# Altium schematic sheet SCH1.SchDoc(SCH1)
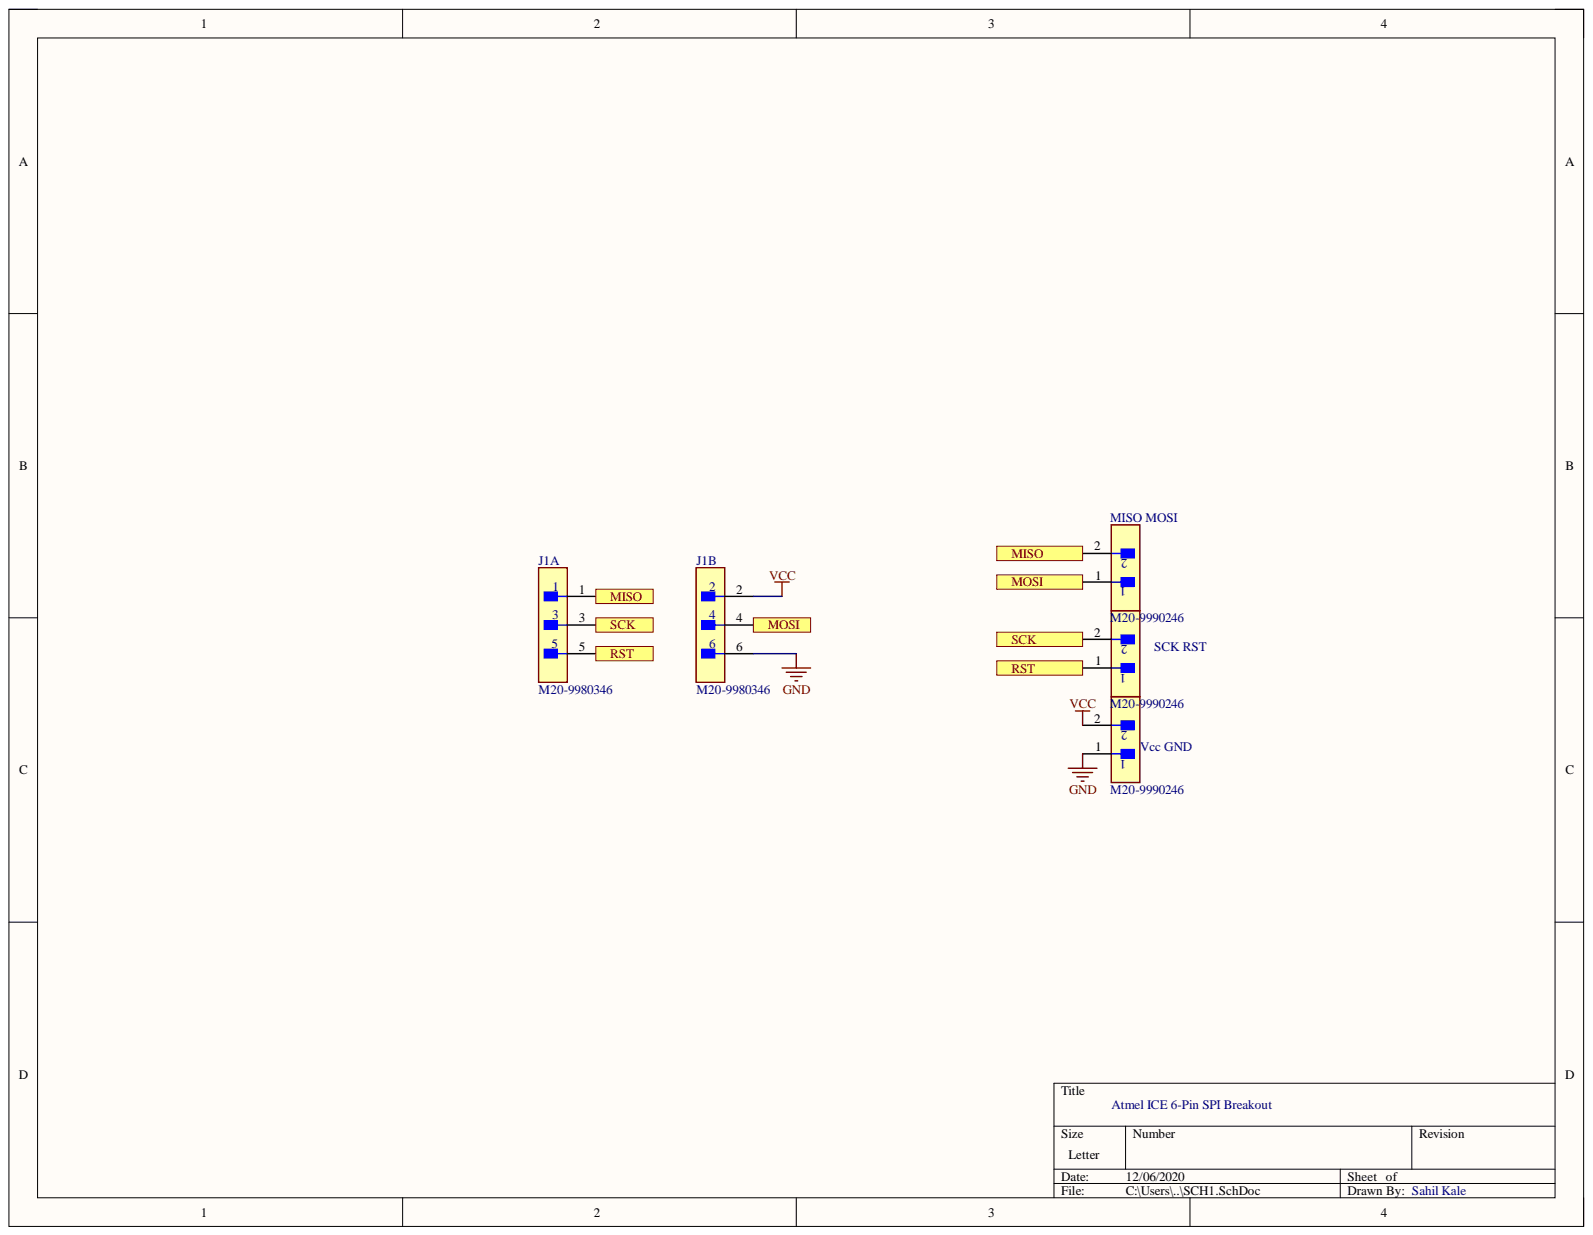
[diagram p0: SCH1.SchDoc]

## Parts
J1  M20-9980346  [FP-M20-9980346-MFG]  (parts A,B)
MISO_MOSI  M20-9990246  [FP-M20-9990246-MFG]
SCK_RST  M20-9990246  [FP-M20-9990246-MFG]
Vcc_GND  M20-9990246  [FP-M20-9990246-MFG]

## Ports
MISO, MOSI, RST, SCK

## Nets
GND: J1.6 Vcc_GND.1
VCC: J1.2 Vcc_GND.2
MISO: J1.1 MISO_MOSI.2 ; port MISO
MOSI: J1.4 MISO_MOSI.1 ; port MOSI
RST: J1.5 SCK_RST.1 ; port RST
SCK: J1.3 SCK_RST.2 ; port SCK

## Library data of the parts
J1 = CMP-2000-06636-2: CONN HEADER VERT 6POS 2.54MM; Manufacturer: Harwin; Conn Gender: Male; Conn Orientation: Vertical; Current Rating: 3A; Number Of Contacts: 6; Automotive: No; Category: Conn; Component Kind: Standard; ComponentLink2Description: Datasheet; ComponentLink2URL: https://cdn.harwin.com/pdfs/M20-998.pdf; Contact Material: Tin,Nickel; Device Class L1: Connectors; Device Class L2: Headers and Wire Housings; Device Class L3: unset; Digikey Description: CONN HEADER VERT 6POS 2.54MM; Height: 8.99mm; Lead Free: Yes; Library Name: Altium Content Vault; Manufacturer Part Number: M20-9980346; Mouser Description: 2.54mm (0.1") Pitch DIL Vertical PC Tail Pin Header, 6.1mm mating pin height, tin, 3+3 contacts; Number Of Rows: 2; Octopart: https://octopart.com/m20-9980346-harwin-5436545; Package: M20-9980346; Pitch: 2.54mm; RoHS: TRUE; Temperature: -40°C to +105°C; Temperature Range High: +105°C; Temperature Range Low: -40°C
MISO_MOSI SCK_RST Vcc_GND = CMP-15831-000009-1: CONN HEADER VERT 2POS 2.54MM; Manufacturer: Harwin; Conn Gender: Male; Conn Orientation: Vertical; Current Rating: 3A; Number Of Contacts: 2; Automotive: No; Category: Conn; Component Kind: Standard; ComponentLink2Description: Datasheet; ComponentLink2URL: https://cdn.harwin.com/pdfs/M20-999.pdf; Contact Material: Tin,Nickel; Device Class L1: Connectors; Device Class L2: Headers and Wire Housings; Device Class L3: unset; Digikey Description: CONN HEADER VERT 2POS 2.54MM; Height: 8.99mm; Lead Free: Yes; Library Name: Altium Content Vault; Manufacturer Part Number: M20-9990246; Mouser Description: 2.54mm (0.1") Pitch SIL Vertical PC Tail Pin Header, 6.1mm mating pin height, tin, 2 contacts; Number Of Rows: 1; Octopart: https://octopart.com/m20-9990246-harwin-2590635; Package: M20-9990246; Pitch: 2.54mm; RoHS: TRUE; Temperature: -40°C to +105°C; Temperature Range High: +105°C; Temperature Range Low: -40°C
